# Altium schematic sheet Pot.SchDoc(Pot)
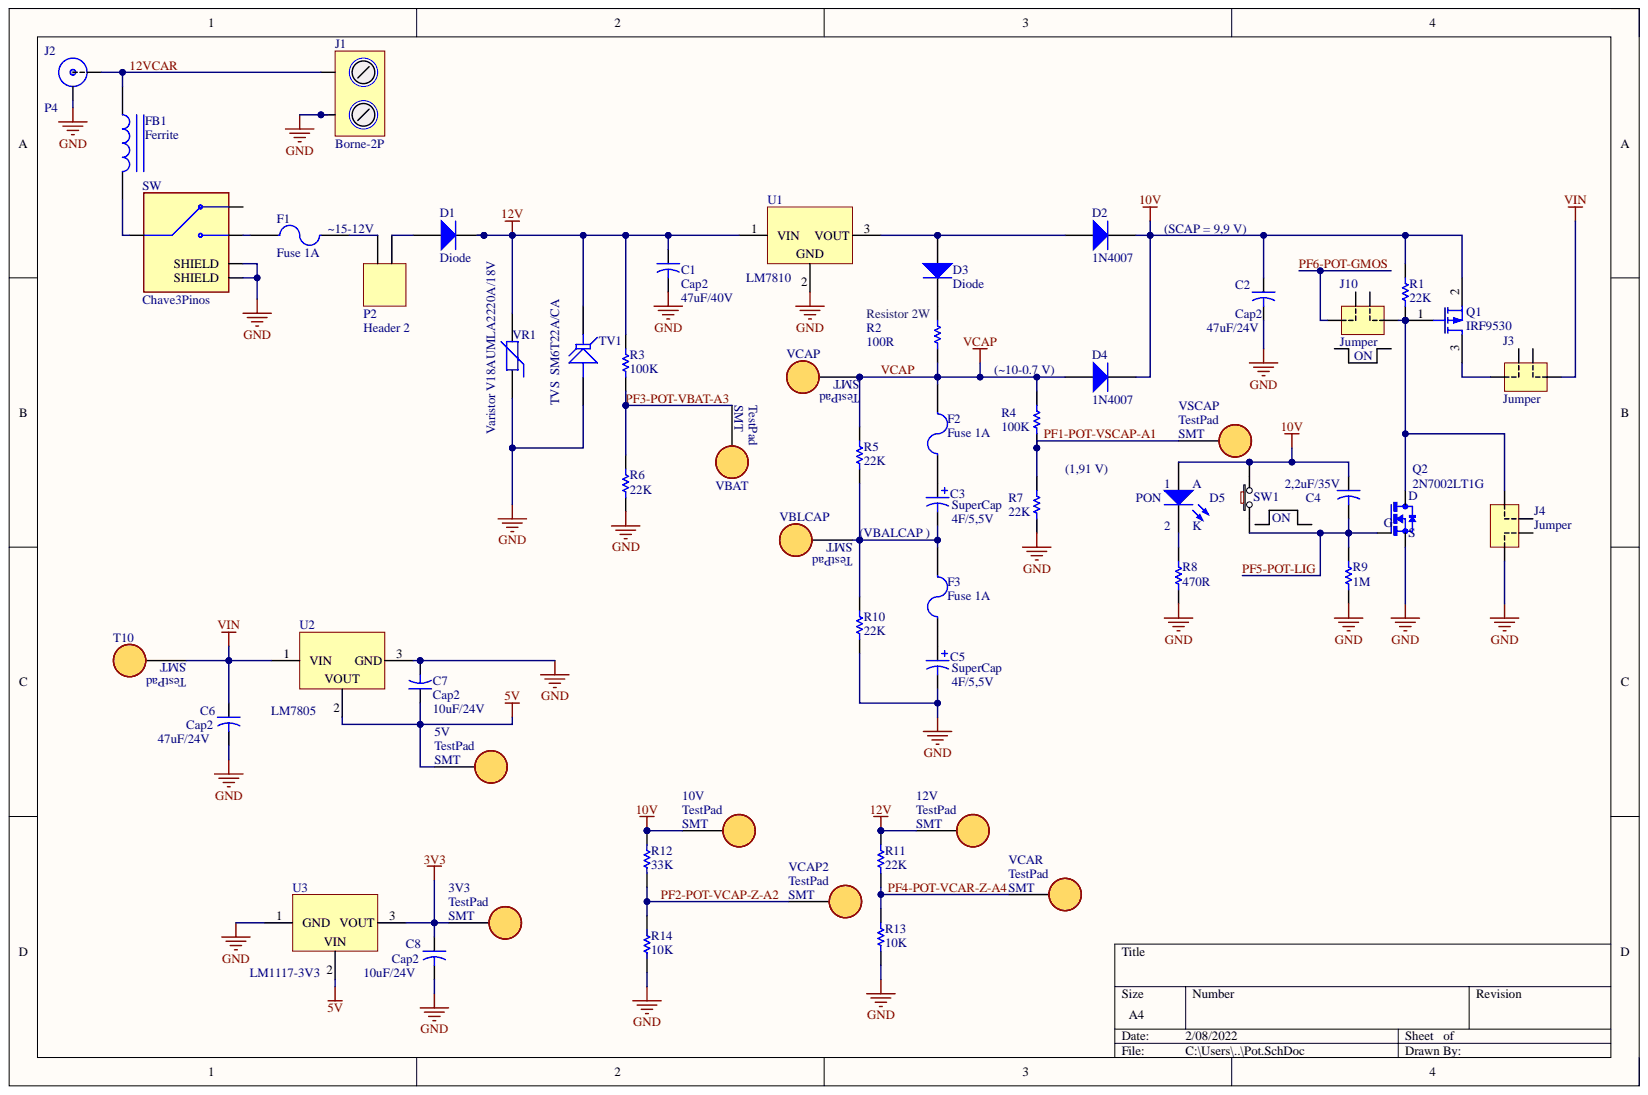
[diagram p0: Pot.SchDoc]

## Parts
3V3  (no value)  [TestPAD-SMT-SIMPLES]
5V  (no value)  [TestPAD-SMT-SIMPLES]
10V  (no value)  [TestPAD-SMT-SIMPLES]
12V  (no value)  [TestPAD-SMT-SIMPLES]
C1  Cap2  value 47uF/40V  [CAP4.7uF35V]
C2  Cap2  value 47uF/24V  [CAP4.7uF25V]
C3  SuperCap  value 4F/5,5V  [SuperCap Duplo]
C4  2,2uF/35V  [CAPGC2012X65N]
C5  SuperCap  value 4F/5,5V  [SuperCap Duplo]
C6  Cap2  value 47uF/24V  [CAP4.7uF25V]
C7  Cap2  value 10uF/24V  [CAPGC2012X65N]
C8  Cap2  value 10uF/24V  [CAPGC2012X65N]
D1  Diode  [DIOM5436X245N]
D2  1N4007  [DIOM5436X245N]
D3  Diode  [DIOM5436X245N]
D4  1N4007  [DIOM5436X245N]
D5  PON  [LED_7012X1]
F1  Fuse 1A  [FUSE_0154004.DR]
F2  Fuse 1A  [RESC1206X70N]
F3  Fuse 1A  [RESC1206X70N]
FB1  Ferrite  [BEADC2012X105N]
J1  Borne-2P  [PCBComponent_1]
J2  P4  [Jack P4]
J3  Jumper  [SIP2]
J4  Jumper  [SIP2]
J10  Jumper  [SIP2]
P2  Header 2  [HDR1X2]
Q1  IRF9530  [TO254P1515X470-3N]
Q2  2N7002LT1G  [SOT23]
R1  22K  [RESC2012X65N]
R2  100R  [DIOAD1898W129L762D1143]
R3  100K  [RESC2012X65N]
R4  100K  [RESC2012X65N]
R5  22K  [RESC2012X65N]
R6  22K  [RESC2012X65N]
R7  22K  [RESC2012X65N]
R8  470R  [RESC2012X65N]
R9  1M  [RESC2012X65N]
R10  22K  [RESC2012X65N]
R11  22K  [RESC2012X65N]
R12  33K  [RESC2012X65N]
R13  10K  [RESC2012X65N]
R14  10K  [RESC2012X65N]
SW  Chave3Pinos  [CHAVE3P-CXP]
SW1  PUSH BUTTON  [SW_PTS636_SM43J_SMTR_LFS]  (value not drawn)
T10  (no value)  [TestPAD-SMT-SIMPLES]
TV1  TVS  SM6T22A/CA  [2220]
U1  LM7810  [TO220]
U2  LM7805  [TO254P1515X470-3N]
U3  LM1117-3V3  [DPAK-TO252]
VBAT  (no value)  [TestPAD-SMT-SIMPLES]
VBLCAP  (no value)  [TestPAD-SMT-SIMPLES]
VCAP  (no value)  [TestPAD-SMT-SIMPLES]
VCAP2  (no value)  [TestPAD-SMT-SIMPLES]
VCAR  (no value)  [TestPAD-SMT-SIMPLES]
VR1  Varistor V18AUMLA2220A/18V  [DIOM5436X245N]
VSCAP  (no value)  [TestPAD-SMT-SIMPLES]

## Nets
3V3: 3V3.1 C8.1 U3.3
5V: 5V.1 C7.1 U2.2 U3.2
10V: 10V.1 C2.1 C4.1 D2.C D4.C D5.A Q1.2 R1.2 R12.2 SW1.2
12V: 12V.1 C1.1 D1.C R3.2 R11.2 TV1.2 U1.1 VR1.C
GND: C1.2 C2.2 C5.2 C6.2 C7.2 C8.2 J1.1 J2.1 J4.2 Q2.2 R6.1 R7.1 R8.1 R9.1 R10.1 R13.1 R14.1 SW.S1(SHIELD) SW.S2(SHIELD) TV1.1 U1.2 U2.3 U3.1 VR1.A
VIN: C6.1 J3.2 T10.1 U2.1
12VCAR: FB1.1 J1.2 J2.2
PF1-POT-VSCAP-A1: R4.1 R7.2 VSCAP.1
PF2-POT-VCAP-Z-A2: R12.1 R14.2 VCAP2.1
PF3-POT-VBAT-A3: R3.1 R6.2 VBAT.1
PF4-POT-VCAR-Z-A4: R11.1 R13.2 VCAR.1
PF5-POT-LIG: C4.2 Q2.1 R9.2 SW1.1
PF6-POT-GMOS: J10.1
VCAP: D4.A F2.2 R2.1 R4.2 R5.2 VCAP.1
NetC3_1: C3.1 F2.1
NetC3_2: C3.2 F3.2 R5.1 R10.2 VBLCAP.1
NetC5_1: C5.1 F3.1
NetD1_A: D1.A P2.1
NetD2_A: D2.A D3.A U1.3
NetD3_C: D3.C R2.2
NetD5_C: D5.C R8.2
NetF1_1: F1.1 SW.2
NetF1_2: F1.2 P2.2
NetFB1_2: FB1.2 SW.1
NetJ3_1: J3.1 Q1.3
NetJ4_1: J4.1 J10.2 Q1.1 Q2.3 R1.1

Not connected: SW 3

## Library data of the parts
3V3 5V 10V 12V T10 VBAT VBLCAP VCAP VCAP2 VCAR VSCAP = TestPad: Component Kind: Standard; Library Name: TestPadSMT.SchLib
C1 C2 C4 C6 C7 = Cap2: Capacitor; Component Kind: Standard; Library Name: Miscellaneous Devices.IntLib; PackageDescription: Capacitor; 2 Leads; PackageReference: CAPR5-4X5; Published: 23-Sep-2002; Signal Integrity: Cap; Simulation: CAP; Publisher: Altium Limited
  C4: Value: 2,2uF/35V
C3 C5 = Cap Pol1: Polarized Capacitor (Radial); Component Kind: Standard; LatestRevisionDate: 17-Jul-2002; LatestRevisionNote: Re-released for DXP Platform.; Library Name: Miscellaneous Devices.IntLib; PackageDescription: Polarized Capacitor (Radial); 2 Leads; PackageReference: RB7.6-15; Published: 8-Jun-2000; Publisher: Altium Limited
C8 = Cap2: Capacitor; Value: 10uF/24V; Component Kind: Standard; Library Name: Miscellaneous Devices.IntLib; PackageDescription: Capacitor; 2 Leads; PackageReference: CAPR5-4X5; Published: 23-Sep-2002; Signal Integrity: Cap; Simulation: CAP; Publisher: Altium Limited
D1 D3 = Diode: Default Diode; Code_JEDEC: DO-214-AB; Component Kind: Standard; LatestRevisionDate: 17-Jul-2002; LatestRevisionNote: Re-released for DXP Platform.; Library Name: Miscellaneous Devices.IntLib; PackageDescription: DO-214-AB/SMC; 2 C-Bend Leads; Body 7.9 x 5.9 mm, inc. leads (LxW); PackageReference: SMC; PackageVersion: Sep-1996; Published: 8-Jun-2000; Signal Integrity: Diode; Simulation: DIODE; Publisher: Altium Limited
D2 D4 = Diode 1N4007: 1 Amp General Purpose Rectifier; Code_JEDEC: DO-204-AL; Component Kind: Standard; ComponentLink1Description: Manufacturer Link; ComponentLink1URL: http://www.fairchildsemi.com/; ComponentLink2Description: Datasheet; ComponentLink2URL: http://www.fairchildsemi.com/ds/1N%2F1N4001.pdf; DatasheetVersion: 1998; LatestRevisionDate: 17-Jul-2002; LatestRevisionNote: Re-released for DXP Platform.; Library Name: Miscellaneous Devices.IntLib; PackageDescription: Diode, Axial; Body 5.2 x 2.7 mm (LxDia. max), Lead Dia. 0.86 mm (max); PackageReference: DO-41; PackageVersion: Aug-1999; Published: 8-Jun-2000; Signal Integrity: 1N4007; Simulation: 1N4007; Publisher: Altium Limited
D5 = LED: Component Kind: Standard; Library Name: Zele_Sch.SchLib
F1-F3 = Fuse 2: Fuse; Component Kind: Standard; LatestRevisionDate: 17-Jul-2002; LatestRevisionNote: Re-released for DXP Platform.; Library Name: Miscellaneous Devices.IntLib; PackageDescription: Thru-Hole; 2 Leads; PackageReference: PIN-W2/E2.8; Published: 8-Jun-2000; Publisher: Altium Limited
FB1 = Inductor Iron: Magnetic-Core Inductor; Component Kind: Standard; LatestRevisionDate: 17-Jul-2002; LatestRevisionNote: Re-released for DXP Platform.; Library Name: Miscellaneous Devices.IntLib; PackageDescription: Axial Device, Thru-Hole; 2 Leads; 0.9 in Pin Spacing; PackageReference: AXIAL-0.9; Published: 24-Mar-1999; Signal Integrity: Inductor Iron; Simulation: INDUCTOR; Publisher: Altium Limited
J3 J4 J10 = JP2: Component Kind: Standard; Library Name: Zele_Sch.SchLib
P2 = Header 2: Header, 2-Pin; Component Kind: Standard; LatestRevisionDate: 17-Jul-2002; LatestRevisionNote: Re-released for DXP Platform.; Library Name: Miscellaneous Connectors.IntLib; Signal Integrity: Connector; Publisher: Altium Limited
Q1 = MOSFET-P: P-Channel MOSFET; Component Kind: Standard; LatestRevisionDate: 17-Jul-2002; LatestRevisionNote: Re-released for DXP Platform.; Library Name: Miscellaneous Devices.IntLib; PackageDescription: TO, Flat Index; 3 In-Line, Axial Leads; Body Dia. 4.6mm; Lead Dia. 0.5 mm (max); PackageReference: E3; PackageVersion: 1995/1996; Published: 8-Jun-2000; Publisher: Altium Limited
Q2 = FDN359AN: N-Channel 30V 2.7A (Ta) 500mW (Ta) Surface Mount SuperSOT-3; MF: ON Semiconductor; Component Kind: Standard; Digi-Key_Part_Number: FDN359ANCT-ND; MP: FDN359AN; Package: SC-59 ON Semiconductor; SnapEDA_Link: https://www.snapeda.com/parts/FDN359AN/ON%20Semiconductor/view-part/1737161/?ref=snap; Library Name: NMOS-SOT23.SchLib
R1 R8 R9 = Res1: Resistor; Value: 100R; Component Kind: Standard; LatestRevisionDate: 17-Jul-2002; LatestRevisionNote: Re-released for DXP Platform.; Library Name: Miscellaneous Devices.IntLib; PackageDescription: Axial Device, Thru-Hole; 2 Leads; 0.3 in Pin Spacing; PackageReference: AXIAL-0.3; Published: 8-Jun-2000; Signal Integrity: Res; Simulation: RESISTOR; Publisher: Altium Limited
R2 R13 R14 = Res1: Resistor; Component Kind: Standard; LatestRevisionDate: 17-Jul-2002; LatestRevisionNote: Re-released for DXP Platform.; Library Name: Miscellaneous Devices.IntLib; PackageDescription: Axial Device, Thru-Hole; 2 Leads; 0.3 in Pin Spacing; PackageReference: AXIAL-0.3; Published: 8-Jun-2000; Signal Integrity: Res; Simulation: RESISTOR; Publisher: Altium Limited
  R2: Value: 100R
  R13 R14: Value: 10K
R3 R11 R12 = Res1: Resistor; Value: 47K; Component Kind: Standard; LatestRevisionDate: 17-Jul-2002; LatestRevisionNote: Re-released for DXP Platform.; Library Name: Miscellaneous Devices.IntLib; PackageDescription: Axial Device, Thru-Hole; 2 Leads; 0.3 in Pin Spacing; PackageReference: AXIAL-0.3; Published: 8-Jun-2000; Signal Integrity: Res; Simulation: RESISTOR; Publisher: Altium Limited
R4 = Res1: Resistor; Value: 33K; Component Kind: Standard; LatestRevisionDate: 17-Jul-2002; LatestRevisionNote: Re-released for DXP Platform.; Library Name: Miscellaneous Devices.IntLib; PackageDescription: Axial Device, Thru-Hole; 2 Leads; 0.3 in Pin Spacing; PackageReference: AXIAL-0.3; Published: 8-Jun-2000; Signal Integrity: Res; Simulation: RESISTOR; Publisher: Altium Limited
R5-R7 R10 = Res1: Resistor; Value: 10K; Component Kind: Standard; LatestRevisionDate: 17-Jul-2002; LatestRevisionNote: Re-released for DXP Platform.; Library Name: Miscellaneous Devices.IntLib; PackageDescription: Axial Device, Thru-Hole; 2 Leads; 0.3 in Pin Spacing; PackageReference: AXIAL-0.3; Published: 8-Jun-2000; Signal Integrity: Res; Simulation: RESISTOR; Publisher: Altium Limited
SW = Chave3Pinos: Chave com 3 pinos(2 estados); MF: TE Connectivity; MP: FSMRA6JH; Availability: Unavailable; Component Kind: Standard; Library Name: Chave3Pinos.SchLib; Package: None; Price: None; SnapEDA_Link: https://www.snapeda.com/parts/FSMRA6JH/TE%20Connectivity%20ALCOSWITCH%20Switches/view-part/590624/?ref=snap
SW1 = PUSHB: Component Kind: Standard; Library Name: Zele_Sch.SchLib
TV1 = Tranzorb: Transient Voltage Suppression (TVS) Diode; Component Kind: Standard; LatestRevisionDate: 17-Jul-2002; LatestRevisionNote: Re-released for DXP Platform.; Library Name: Miscellaneous Devices.IntLib; PackageDescription: Axial Lead Diode; PackageReference: DO-7; Published: 24-Mar-1999; Publisher: Altium Limited
U1 U2 = 7805: Component Kind: Standard
  U1: Library Name: Miscellaneous Devices.IntLib
  U2: Library Name: Zele_Sch.SchLib
VR1 = Res Varistor: Varistor (Voltage-Sensitive Resistor); Code_IPC: RESC2012; Component Kind: Standard; LatestRevisionDate: 29-May-2009; LatestRevisionNote: IPC-7351 Footprint Added.; Library Name: Miscellaneous Devices.IntLib; PackageDescription: Chip Resistor 0805 Case Size; PackageReference: 6-0805; PackageVersion: Mar-2003; Published: 24-Mar-1999; Publisher: Altium Limited
J1 = Borne-2P: Component Kind: Standard; Library Name: Zele_Sch.SchLib
J2 = P4: Component Kind: Standard; Library Name: Zele_Sch.SchLib
U3 = LM1117-3V3: Component Kind: Standard; Library Name: Zele_Sch.SchLib
